# Altium schematic sheet [3]_USB_Interface.SchDoc([3]_USB_Interface)
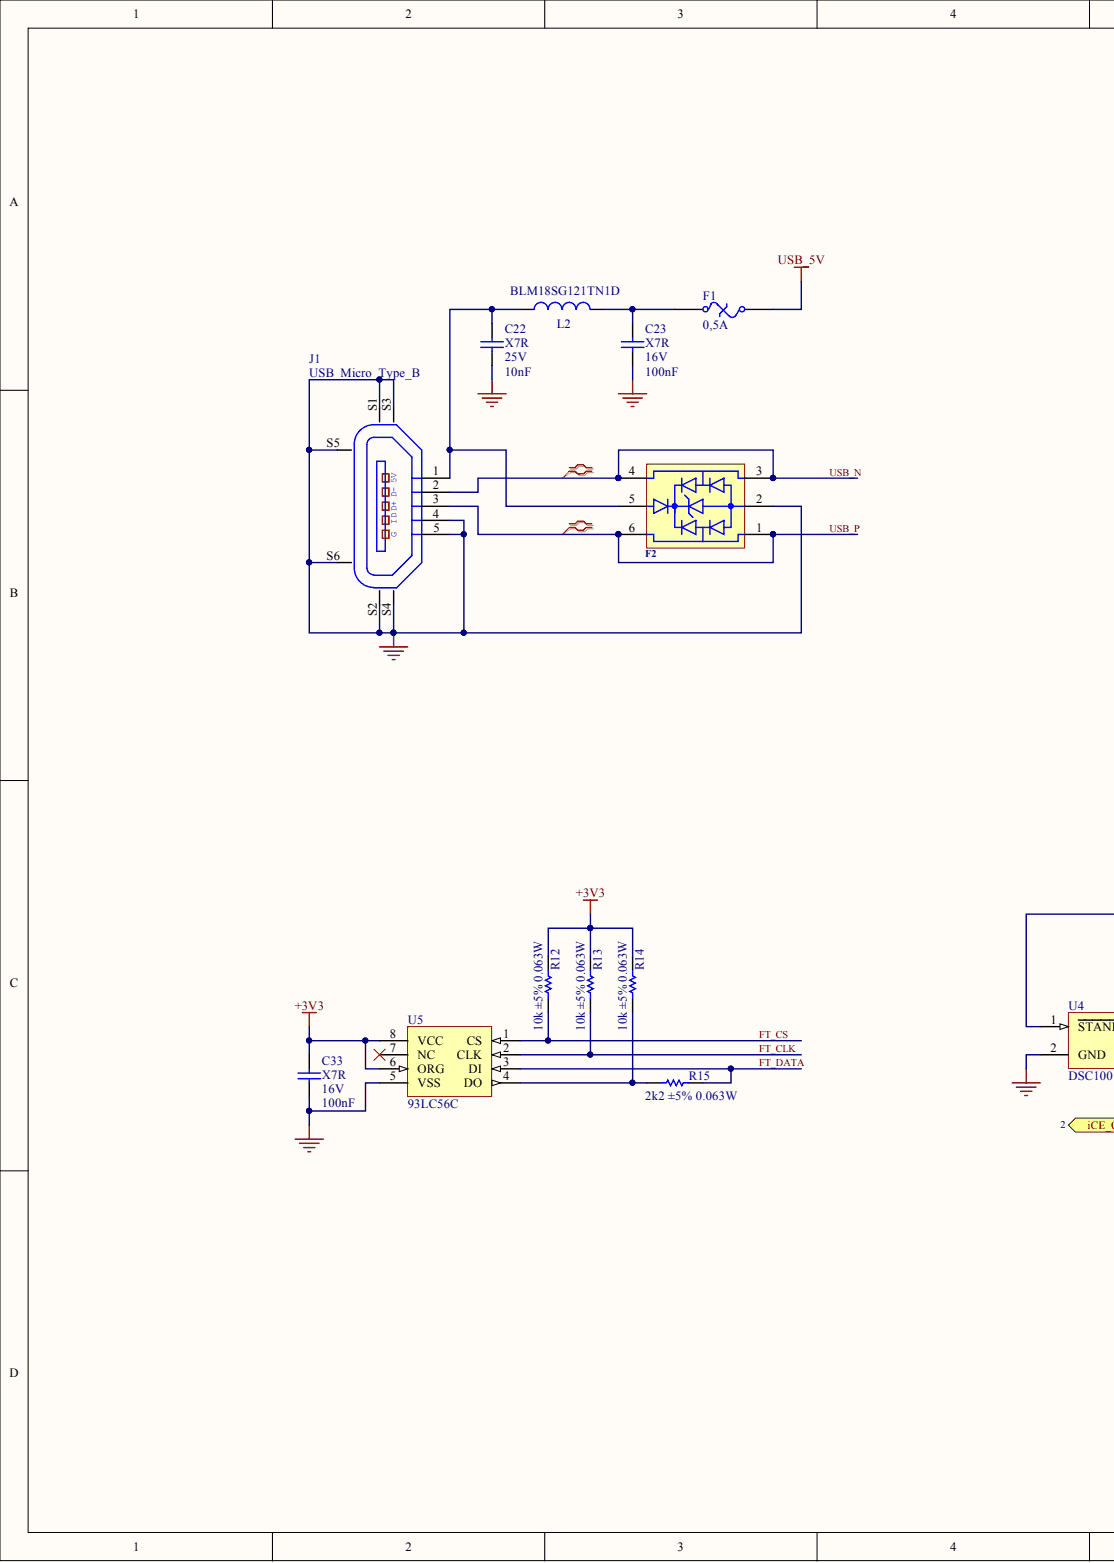
[diagram p0: [3]_USB_Interface.SchDoc, left]
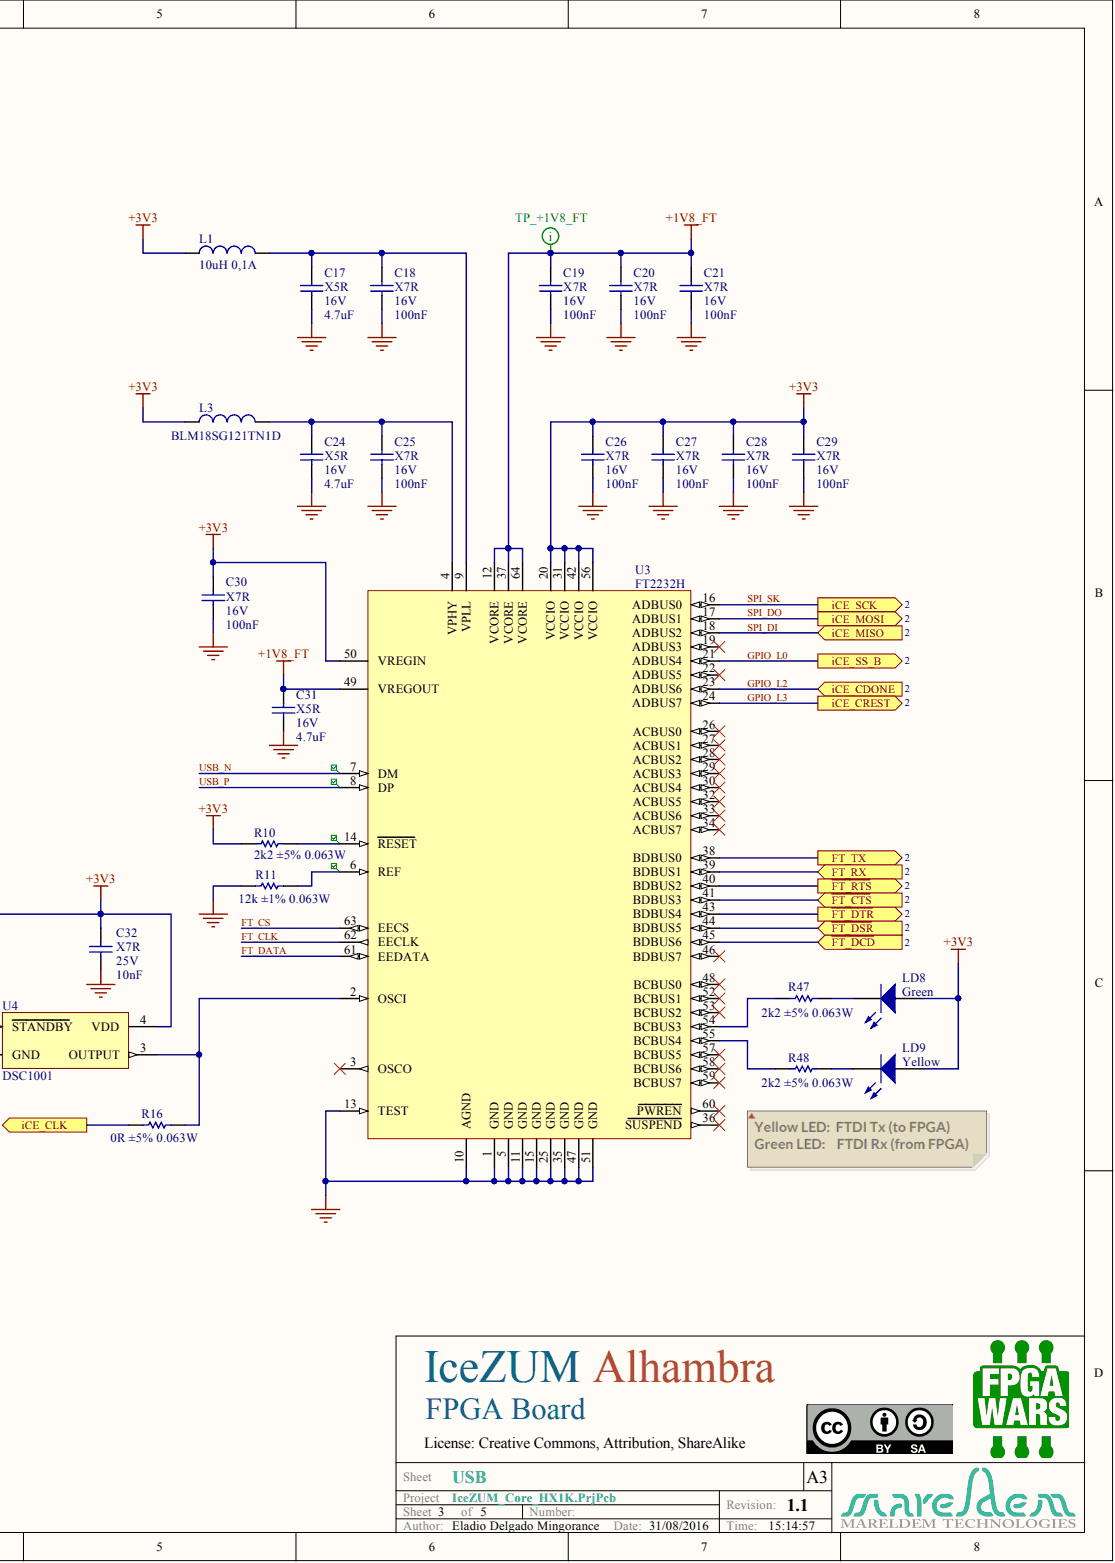
[diagram p1: [3]_USB_Interface.SchDoc, right]

## Parts
C17  Capacitance: 4.7uF  Dielectric: X5R  Voltage: 16V  [C0603]  (hidden comment: Capacitor)
C18  Capacitance: 100nF  Dielectric: X7R  Voltage: 16V  [C0402]  (hidden comment: Capacitor)
C19  Capacitance: 100nF  Dielectric: X7R  Voltage: 16V  [C0402]  (hidden comment: Capacitor)
C20  Capacitance: 100nF  Dielectric: X7R  Voltage: 16V  [C0402]  (hidden comment: Capacitor)
C21  Capacitance: 100nF  Dielectric: X7R  Voltage: 16V  [C0402]  (hidden comment: Capacitor)
C22  Capacitance: 10nF  Dielectric: X7R  Voltage: 25V  [C0603]  (hidden comment: Capacitor)
C23  Capacitance: 100nF  Dielectric: X7R  Voltage: 16V  [C0402]  (hidden comment: Capacitor)
C24  Capacitance: 4.7uF  Dielectric: X5R  Voltage: 16V  [C0603]  (hidden comment: Capacitor)
C25  Capacitance: 100nF  Dielectric: X7R  Voltage: 16V  [C0402]  (hidden comment: Capacitor)
C26  Capacitance: 100nF  Dielectric: X7R  Voltage: 16V  [C0402]  (hidden comment: Capacitor)
C27  Capacitance: 100nF  Dielectric: X7R  Voltage: 16V  [C0402]  (hidden comment: Capacitor)
C28  Capacitance: 100nF  Dielectric: X7R  Voltage: 16V  [C0402]  (hidden comment: Capacitor)
C29  Capacitance: 100nF  Dielectric: X7R  Voltage: 16V  [C0402]  (hidden comment: Capacitor)
C30  Capacitance: 100nF  Dielectric: X7R  Voltage: 16V  [C0402]  (hidden comment: Capacitor)
C31  Capacitance: 4.7uF  Dielectric: X5R  Voltage: 16V  [C0603]  (hidden comment: Capacitor)
C32  Capacitance: 10nF  Dielectric: X7R  Voltage: 25V  [C0603]  (hidden comment: Capacitor)
C33  Capacitance: 100nF  Dielectric: X7R  Voltage: 16V  [C0402]  (hidden comment: Capacitor)
F1  0,5A  [F0805]
F2  ESDUSB  [SOT563]  (value not drawn)
J1  USB_Micro_Type_B  [USB_Micro_B]
L1  10uH 0,1A  Current: 0,1A  L: 10uH  [L0805]
L2  BLM18SG121TN1D  [L0603]
L3  BLM18SG121TN1D  [L0603]
LD8  Green  [LED0603]
LD9  Yellow  [LED0603]
R10  value 2k2 ±5% 0.063W  Resistance: 2k2  Tolerance: ±5%  [R0402]  (hidden comment: Resistor)
R11  value 12k ±1% 0.063W  Power: 0.063W  Resistance: 12k  Tolerance: ±1%  [R0402]  (hidden comment: Resistor)
R12  value 10k ±5% 0.063W  Power: 0.063W  Resistance: 10k  Tolerance: ±5%  [R0402]  (hidden comment: Resistor)
R13  value 10k ±5% 0.063W  Power: 0.063W  Resistance: 10k  Tolerance: ±5%  [R0402]  (hidden comment: Resistor)
R14  value 10k ±5% 0.063W  Power: 0.063W  Resistance: 10k  Tolerance: ±5%  [R0402]  (hidden comment: Resistor)
R15  value 2k2 ±5% 0.063W  Power: 0.063W  Resistance: 2k2  Tolerance: ±5%  [R0402]  (hidden comment: Resistor)
R16  value 0R ±5% 0.063W  Power: 0.063W  Resistance: 0R  Tolerance: ±5%  [R0402]  (hidden comment: Resistor)
R47  value 2k2 ±5% 0.063W  Resistance: 2k2  Tolerance: ±5%  [R0402]  (hidden comment: Resistor)
R48  value 2k2 ±5% 0.063W  Resistance: 2k2  Tolerance: ±5%  [R0402]  (hidden comment: Resistor)
U3  FT2232H  [FTDI_QFN-64]
U4  DSC1001  [OSC 3.2x2.5]
U5  93LC56C  [MSOP8]

## Ports
FT_RX, FT_TX, ICE_CDONE, ICE_CLK, ICE_CREST, ICE_MISO, ICE_MOSI, ICE_SCK, ICE_SS_B, ~{FT_CTS}, ~{FT_DCD}, ~{FT_DSR}, ~{FT_DTR}, ~{FT_RTS}

## Nets
+1V8_FT: C19.2 C20.2 C21.2 C31.2 U3.12(VCORE) U3.37(VCORE) U3.49(VREGOUT) U3.64(VCORE)
+3V3: C26.2 C27.2 C28.2 C29.2 C30.2 C32.2 C33.2 L1.1 L3.1 LD8.A LD9.A R10.2 R12.2 R13.2 R14.2 U3.20(VCCIO) U3.31(VCCIO) U3.42(VCCIO) U3.50(VREGIN) U3.56(VCCIO) U4.1(STANDBY) U4.4(VDD) U5.6(ORG) U5.8(VCC)
GND: C17.1 C18.1 C19.1 C20.1 C21.1 C22.1 C23.1 C24.1 C25.1 C26.1 C27.1 C28.1 C29.1 C30.1 C31.1 C32.1 C33.1 F2.2 J1.4 J1.5 J1.S1 J1.S2 J1.S3 J1.S4 J1.S5 J1.S6 R11.2 U3.1(GND) U3.5(GND) U3.10(AGND) U3.11(GND) U3.13(TEST) U3.15(GND) U3.25(GND) U3.35(GND) U3.47(GND) U3.51(GND) U4.2(GND) U5.5(VSS)
USB_5V: F1.2
~{FT_CTS}: U3.41(BDBUS3) ; port ~{FT_CTS}
~{FT_DCD}: U3.45(BDBUS6) ; port ~{FT_DCD}
~{FT_DSR}: U3.44(BDBUS5) ; port ~{FT_DSR}
~{FT_DTR}: U3.43(BDBUS4) ; port ~{FT_DTR}
~{FT_RTS}: U3.40(BDBUS2) ; port ~{FT_RTS}
FT_CLK: R13.1 U3.62(EECLK) U5.2(CLK)
FT_CS: R12.1 U3.63(EECS) U5.1(CS)
FT_DATA: R15.1 U3.61(EEDATA) U5.3(DI)
FT_RX: U3.39(BDBUS1) ; port FT_RX
FT_TX: U3.38(BDBUS0) ; port FT_TX
GPIO_L0: U3.21(ADBUS4) ; port ICE_SS_B
GPIO_L2: U3.23(ADBUS6) ; port ICE_CDONE
GPIO_L3: U3.24(ADBUS7) ; port ICE_CREST
SPI_DI: U3.18(ADBUS2) ; port ICE_MISO
SPI_DO: U3.17(ADBUS1) ; port ICE_MOSI
SPI_SK: U3.16(ADBUS0) ; port ICE_SCK
USB_N: F2.3 F2.4 J1.2 U3.7(DM)
USB_P: F2.1 F2.6 J1.3 U3.8(DP)
NetC17_2: C17.2 C18.2 L1.2 U3.9(VPLL)
NetC22_2: C22.2 F2.5 J1.1 L2.1
NetC23_2: C23.2 F1.1 L2.2
NetC24_2: C24.2 C25.2 L3.2 U3.4(VPHY)
NetLD8_K: LD8.K R47.2
NetLD9_K: LD9.K R48.2
NetR10_1: R10.1 U3.14(RESET)
NetR11_1: R11.1 U3.6(REF)
NetR14_1: R14.1 R15.2 U5.4(DO)
NetR16_1: R16.1 U3.2(OSCI) U4.3(OUTPUT)
NetR16_2: R16.2 ; port ICE_CLK
NetR47_1: R47.1 U3.54(BCBUS3)
NetR48_1: R48.1 U3.55(BCBUS4)

Not connected: U3 3(OSCO) 19(ADBUS3) 22(ADBUS5) 26(ACBUS0) 27(ACBUS1) 28(ACBUS2) 29(ACBUS3) 30(ACBUS4) 32(ACBUS5) 33(ACBUS6) 34(ACBUS7) 36(SUSPEND) 46(BDBUS7) 48(BCBUS0) 52(BCBUS1) 53(BCBUS2) 57(BCBUS5) 58(BCBUS6) 59(BCBUS7) 60(PWREN); U5 7(NC)

## Library data of the parts
C17 C24 C31 = C0603_4.7uF_16V_±10%: Capacitor SMD; Manufacturer: TDK; Database Table Name: C0603_16V$; OrderingCode: C1608X5R1C475K080AC; Temp_ Max: 85; Temp_ Min: -55; Tolerance: ±10%; Value: 4.7uF ±10% 16V; Component Kind: Standard; Library Name: Pasives_Capacitor_SMD.DbLib; Part Number: C0603_4.7uF_16V_±10%; Price (€): 0,068
C18-C21 C23 C25-C30 C33 = C0402_100nF_16V_±10%: Capacitor SMD; Manufacturer: Kemet; Database Table Name: C0402_16V$; OrderingCode: C0402C104K4RAC; Temp_ Max: 125; Temp_ Min: -55; Tolerance: ±10%; Value: 100nF ±10% 16V; Component Kind: Standard; Library Name: Pasives_Capacitor_SMD.DbLib; Part Number: C0402_100nF_16V_±10%; Price (€): 0,05
C22 = C0603_10nF_25V_±10%: Manufacturer: Kemet; Database Table Name: C0603_25V$; OrderingCode: C0603C103K3RAC; Temp_ Max: 125; Temp_ Min: -55; Tolerance: ±10%; Value: 10nF ±10% 25V; Component Kind: Standard; Description: Capacitor; Library Name: Pasives_Capacitor_SMD.DbLib; Part Number: C0603_10nF_25V_±10%; Price (€): 0,035
C32 = C0603_10nF_25V_±10%: Capacitor SMD; Manufacturer: Kemet; Database Table Name: C0603_25V$; OrderingCode: C0603C103K3RAC; Temp_ Max: 125; Temp_ Min: -55; Tolerance: ±10%; Value: 10nF ±10% 25V; Component Kind: Standard; Library Name: Pasives_Capacitor_SMD.DbLib; Part Number: C0603_10nF_25V_±10%; Price (€): 0,035
F1 = PTC Fuse 0.5A 6V 0805: Protection, PTC Resettable Fuse; Manufacturer: Bourns; Database Table Name: Fuse$; OrderingCode: MF-PSMF050X-2; Temp_ Max: 85; Temp_ Min: -40; Voltage: 6V; Component Kind: Standard; Current: 0,5A; Library Name: Protection.DbLib; Part Number: PTC Fuse 0.5A 6V 0805; Price (€): 0,17
F2 = USB ESD Single: Protection, USB ESD; Manufacturer: Würth; Database Table Name: ESD$; OrderingCode: 82400152; OrderingCode 2: CDSOT563-0502; Temp_ Max: 125; Temp_ Min: -40; Component Kind: Standard; Library Name: Protection.SVNDbLib; Manufacturer 2: Bourns; Part Number: USB ESD Single; Price (€): 0,1; Supplier: Würth
J1 = Micro USB Type B SMD: Connector, USB Micro B; Manufacturer: FCI; Database Table Name: USB$; OrderingCode: 10118192-0001LF; OrderingCode 2: ZX62-B-5PA-11; Temp_ Max: 85; Temp_ Min: -30; Component Kind: Standard; Library Name: Connector_USB.DbLib; Manufacturer 2: Hirose Connector; Part Number: Micro USB Type B SMD; Price (€): 0,11; Supplier: Abacus
L1 = 10uH_0,1A: Inductor SMD; Manufacturer: muRata; Database Table Name: L0805$; OrderingCode: LQM21FN100M70L; Rdc: 0,60R; Temp_ Max: 125; Temp_ Min: -55; Component Kind: Standard; Library Name: Pasives_Inductor_SMD.DbLib; Part Number: 10uH_0,1A; Price (€): 0,062; Value: 10uH 0,1A
L2 = L0603_120R_0,025R_3000mA: Manufacturer: Murata; Current: 3000mA; Database Table Name: Ferritas$; Resistance_100MHz: 120R; Resistance_DC: 0,025R; Temp_ Max: 125; Temp_ Min: -55; Value: 120R 0,025R 3000mA; Component Kind: Standard; Library Name: Pasives_Inductor_SMD.DbLib; OrderingCode: BLM18SG121TN1D; Part Number: L0603_120R_0,025R_3000mA; Price (€): 0,053
L3 = L0603_120R_0,025R_3000mA: Ferrite Bead; Manufacturer: Murata; Current: 3000mA; Database Table Name: Ferritas$; Resistance_100MHz: 120R; Resistance_DC: 0,025R; Temp_ Max: 125; Temp_ Min: -55; Value: 120R 0,025R 3000mA; Component Kind: Standard; Library Name: Pasives_Inductor_SMD.DbLib; OrderingCode: BLM18SG121TN1D; Part Number: L0603_120R_0,025R_3000mA; Price (€): 0,053
LD8 = LED Green 0603: LED; Manufacturer: OSRAM Opto; Database Table Name: LED_SMD$; OrderingCode: LG Q396-PS-35; Temp_ Max: 85; Temp_ Min: -30; Component Kind: Standard; Library Name: Optoelectronics.DbLib; Part Number: LED Green 0603; Price (€): 0,058; Value: Green
LD9 = LED Yellow 0603: LED; Manufacturer: KINGBRIGHT; Database Table Name: LED_SMD$; OrderingCode: APT1608SYCK; Temp_ Max: 85; Temp_ Min: -40; Component Kind: Standard; Library Name: Optoelectronics.DbLib; Part Number: LED Yellow 0603; Price (€): 0,06; Value: Yellow
R10 R47 R48 = R0402_2k2_0.063W_±5%: Resistor, SMD; Manufacturer: Vishay; Database Table Name: R0402_5%$; OrderingCode: CRCW04022K20JN; Power: 0.063W; Temp_ Max: 155; Temp_ Min: -55; Value: 2k2 ±5% 0.063W; Component Kind: Standard; Library Name: Pasives_Resistor_SMD.DbLib; PackageDescription: SMD; Part Number: R0402_2k2_0.063W_±5%; Price (€): 0,003
R11 = R0402_12k_0.063W_±1%: Resistor, SMD; Manufacturer: Vishay; Database Table Name: R0402_1%$; OrderingCode: CRCW040212K0FK; Temp_ Max: 155; Temp_ Min: -55; Component Kind: Standard; Library Name: Pasives_Resistor_SMD.DbLib; PackageDescription: SMD; Part Number: R0402_12k_0.063W_±1%; Price (€): 0,006
R12-R14 = R0402_10k_0.063W_±5%: Resistor, SMD; Manufacturer: Vishay; Database Table Name: R0402_5%$; OrderingCode: CRCW040210K0JN; Temp_ Max: 155; Temp_ Min: -55; Component Kind: Standard; Library Name: Pasives_Resistor_SMD.DbLib; PackageDescription: SMD; Part Number: R0402_10k_0.063W_±5%; Price (€): 0,003
R15 = R0402_2k2_0.063W_±5%: Resistor, SMD; Manufacturer: Vishay; Database Table Name: R0402_5%$; OrderingCode: CRCW04022K20JN; Temp_ Max: 155; Temp_ Min: -55; Component Kind: Standard; Library Name: Pasives_Resistor_SMD.DbLib; PackageDescription: SMD; Part Number: R0402_2k2_0.063W_±5%; Price (€): 0,003
R16 = R0402_0R_0.063W_±5%: Resistor, SMD; Manufacturer: Panasonic; Database Table Name: R0402_5%$; OrderingCode: ERJ-2GE0R00X; OrderingCode 2: CRCW04020000Z0; Temp_ Max: 155; Temp_ Min: -55; Component Kind: Standard; Library Name: Pasives_Resistor_SMD.DbLib; Manufacturer 2: Vishay; PackageDescription: SMD; Part Number: R0402_0R_0.063W_±5%; Price (€): 0,003
U3 = FT2232H: Dual High Speed USB to Multipurpose UART/FIFO IC; Manufacturer: FTDI; Database Table Name: USB$; OrderingCode: FT2232HQ-REEL; Temp_ Max: 85; Temp_ Min: -40; Component Kind: Standard; Library Name: Transceiver.DbLib; Part Number: FT2232H; Price (€): 3,45; Supplier: Silica
U4 = DSC1001-12MHZ: Oscillator, Low-Power CMOS, 4 pin, 1.8-3.3V; Manufacturer: Microchip; Database Table Name: Osciladores$; OrderingCode: DSC1001CL2-012.0000; Temp_ Max: 105; Temp_ Min: -40; Value: 12MHz; Component Kind: Standard; Part Number: DSC1001-12MHZ; Price (€): 0,61; Library Name: Frequency.DbLib
U5 = 93LC56C: EEPROM, 2K Microwire Compatible Serial, 8 or 16 bit word size, 2.5-5.5 Vcc range; Manufacturer: Microchip; Database Table Name: Microwire$; OrderingCode: 93LC56CT-I/MS; Temp_ Max: 85; Temp_ Min: -40; Value: 2Kb; Component Kind: Standard; Library Name: Memory_Serial.DbLib; Part Number: 93LC56C; Price (€): 0,24; Supplier: Silica
